# Altium schematic sheet LEDs.SchDoc
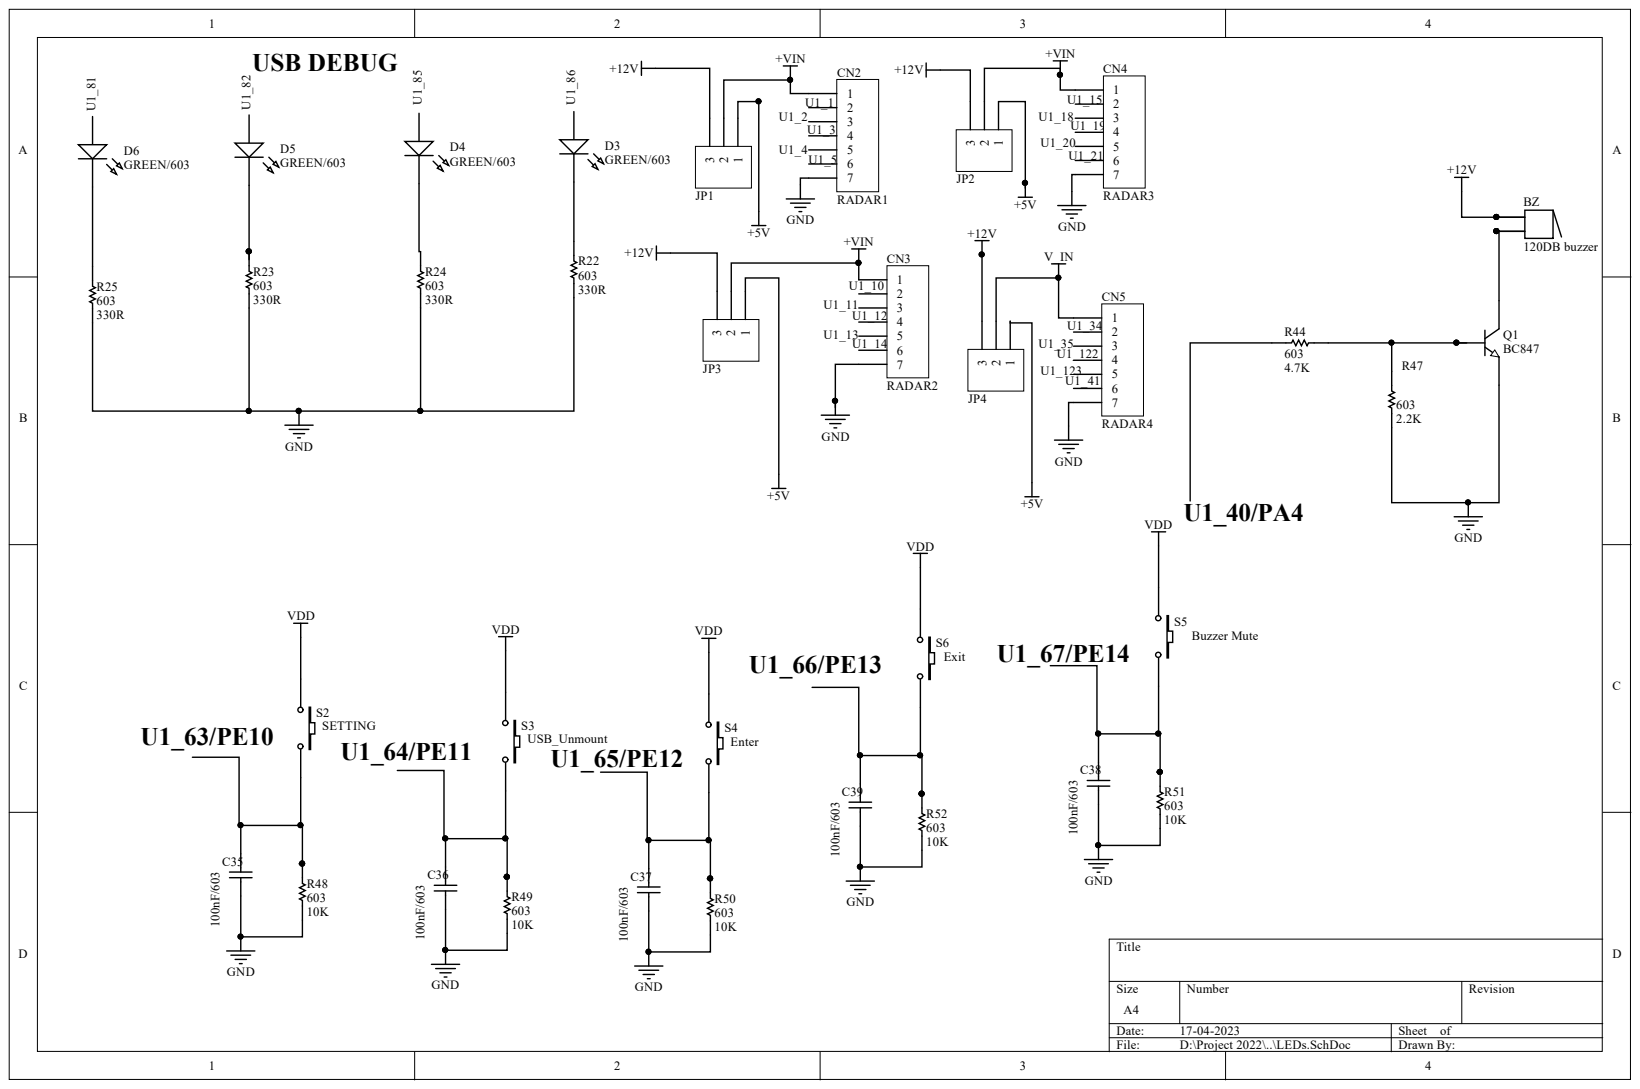
[diagram p0: LEDs.SchDoc]

## Parts
BZ  120DB buzzer  [ABSM-1574]
C35  value 100nF/603  [RAD-0.3]
C36  value 100nF/603  [RAD-0.3]
C37  value 100nF/603  [RAD-0.3]
C38  value 100nF/603  [RAD-0.3]
C39  value 100nF/603  [RAD-0.3]
CN2  RADAR1  [HDR1X7]
CN3  RADAR2  [HDR1X7]
CN4  RADAR3  [HDR1X7]
CN5  RADAR4  [HDR1X7]
D3  GREEN/603  [3.2X1.6X1.1]
D4  GREEN/603  [3.2X1.6X1.1]
D5  GREEN/603  [3.2X1.6X1.1]
D6  GREEN/603  [3.2X1.6X1.1]
JP1  (no value)  [HDR1X3H]
JP2  (no value)  [HDR1X3H]
JP3  (no value)  [HDR1X3H]
JP4  (no value)  [HDR1X3H]
Q1  BC847  [TO-226-AA]
R22  603  value 330R  [AXIAL-0.3]
R23  603  value 330R  [AXIAL-0.3]
R24  603  value 330R  [AXIAL-0.3]
R25  603  value 330R  [AXIAL-0.3]
R44  603  value 4.7K  [AXIAL-0.3]
R47  603  value 2.2K  [AXIAL-0.3]
R48  603  value 10K  [AXIAL-0.3]
R49  603  value 10K  [AXIAL-0.3]
R50  603  value 10K  [AXIAL-0.3]
R51  603  value 10K  [AXIAL-0.3]
R52  603  value 10K  [AXIAL-0.3]
S2  SETTING  [SPST-2]
S3  USB_Unmount  [SPST-2]
S4  Enter  [SPST-2]
S5  Buzzer Mute  [SPST-2]
S6  Exit  [SPST-2]

## Nets
+5V: JP1.1 JP2.1 JP3.1 JP4.1
+12V: BZ.1 BZ.1A JP1.3 JP2.3 JP3.3 JP4.3
+VIN: CN2.1 CN3.1 CN4.1 JP1.2 JP2.2 JP3.2
GND: C35.1 C36.1 C37.1 C38.1 C39.1 CN2.7 CN3.7 CN4.7 CN5.7 Q1.3 R22.2 R23.2 R24.2 R25.2 R47.2 R48.1 R49.1 R50.1 R51.1 R52.1
V_IN: CN5.1 JP4.2
VDD: S2.1 S3.1 S4.1 S5.1 S6.1
NetBZ_2: BZ.2 BZ.2A Q1.1
NetC35_2: C35.2 R48.2 S2.2
NetC36_2: C36.2 R49.2 S3.2
NetC37_2: C37.2 R50.2 S4.2
NetC38_2: C38.2 R51.2 S5.2
NetC39_2: C39.2 R52.2 S6.2
NetD3_2: D3.2 R22.1
NetD4_2: D4.2 R24.1
NetD5_2: D5.2 R23.1
NetD6_2: D6.2 R25.1
NetQ1_2: Q1.2 R44.2 R47.1

Not connected: CN2 2 3 4 5 6; CN3 2 3 4 5 6; CN4 2 3 4 5 6; CN5 2 3 4 5 6; D3 1; D4 1; D5 1; D6 1; R44 1

## Library data of the parts
BZ = Buzzer: Magnetic Transducer Buzzer; Component Kind: Standard; ComponentLink1Description: Manufacturer Link; ComponentLink1URL: http://www.megastar.com/linecard/buzzmode/index.php; DatasheetVersion: 17-Dec-2003; LatestRevisionDate: 17-Dec-2003; LatestRevisionNote: Schematic revised, PCB Footprint replaced.; Library Name: Miscellaneous Devices.IntLib; PackageDescription: Magnetic Transducer Buzzer; PackageReference: ABSM-1574; Published: 24-Mar-1999; Publisher: Altium Limited
C35-C39 = Cap: Capacitor; Component Kind: Standard; LatestRevisionDate: 17-Jul-2002; LatestRevisionNote: Re-released for DXP Platform.; Library Name: Miscellaneous Devices.IntLib; PackageDescription: Radial Cap, Thru-Hole; 2 Leads; 0.3 in Pin Spacing; PackageReference: RAD-0.3; Published: 8-Jun-2000; Signal Integrity: Cap; Simulation: CAP; Publisher: Altium Limited
CN2-CN5 = Header 7: Header, 7-Pin; Component Kind: Standard; LatestRevisionDate: 17-Jul-2002; LatestRevisionNote: Re-released for DXP Platform.; Library Name: Miscellaneous Connectors.IntLib; Signal Integrity: Connector; Publisher: Altium Limited
D3-D6 = LED2: Typical RED, GREEN, YELLOW, AMBER GaAs LED; Component Kind: Standard; LatestRevisionDate: 17-Jul-2002; LatestRevisionNote: Re-released for DXP Platform.; Library Name: Miscellaneous Devices.IntLib; PackageDescription: SM LED; 2 Flat Leads; PackageReference: 3.2X1.6X1.1; PackageVersion: Feb-2002; Published: 8-Jun-2000; Simulation: LED2; Publisher: Altium Limited
JP1-JP4 = Header 3H: Header, 3-Pin, Right Angle; Component Kind: Standard; LatestRevisionDate: 17-Jul-2002; LatestRevisionNote: Re-released for DXP Platform.; Library Name: Miscellaneous Connectors.IntLib; Signal Integrity: Connector; Publisher: Altium Limited
Q1 = NPN: NPN Bipolar Transistor; Code_JEDEC: TO-226-AA; Component Kind: Standard; LatestRevisionDate: 17-Jul-2002; LatestRevisionNote: Re-released for DXP Platform.; Library Name: Miscellaneous Devices.IntLib; PackageDescription: TO, Flat Index; 3 In-Line, Axial Leads; Body Dia. 4.8mm; Leads 0.55 x 0.51 mm (max); PackageReference: TO-226-AA; Published: 8-Jun-2000; Signal Integrity: NPN; Simulation: NPN; Publisher: Altium Limited
R22-R25 R44 R47-R52 = Res1: Resistor; Component Kind: Standard; LatestRevisionDate: 17-Jul-2002; LatestRevisionNote: Re-released for DXP Platform.; Library Name: Miscellaneous Devices.IntLib; PackageDescription: Axial Device, Thru-Hole; 2 Leads; 0.3 in Pin Spacing; PackageReference: AXIAL-0.3; Published: 8-Jun-2000; Signal Integrity: Res; Simulation: RESISTOR; Publisher: Altium Limited
S2-S6 = SW-PB: Switch; Component Kind: Standard; LatestRevisionDate: 17-Jul-2002; LatestRevisionNote: Re-released for DXP Platform.; Library Name: Miscellaneous Devices.IntLib; PackageDescription: Switch; 2 Leads; PackageReference: SPST-2; Published: 24-Mar-1999; Publisher: Altium Limited
